# Altium schematic sheet COMMUNICATION.SchDoc(COMMUNICATION)
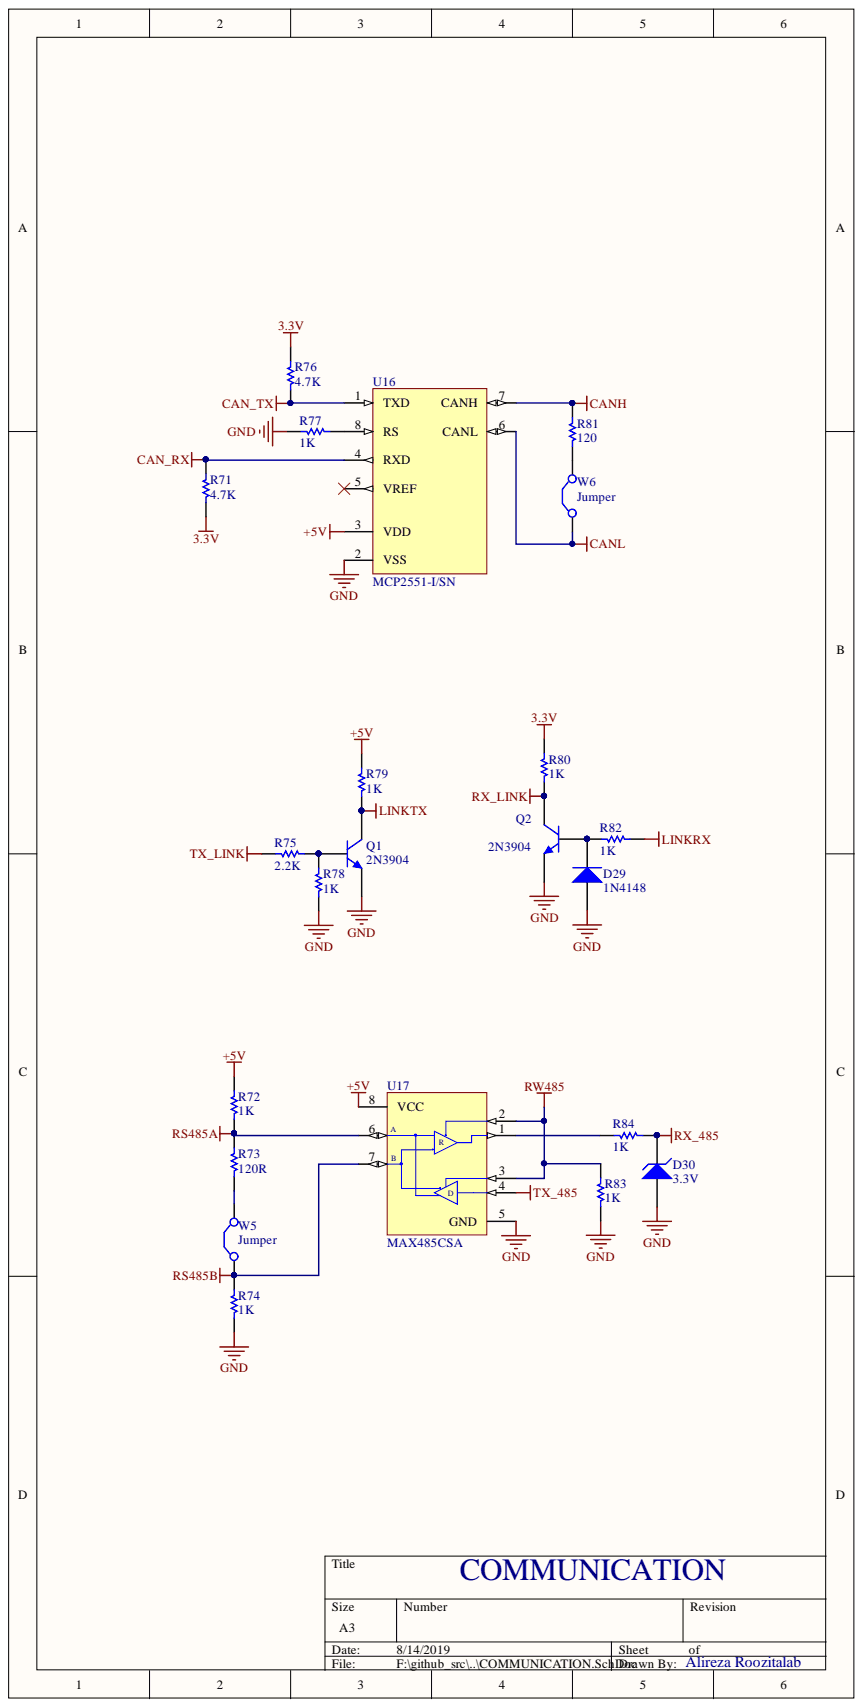
[diagram p0: COMMUNICATION.SchDoc]

## Parts
D29  1N4148  [Diode-1n4148 - SMD PAD]
D30  3.3V  [Diode-1n4148 - SMD PAD]
Q1  2N3904  [2N3906_SMD]
Q2  2N3904  [2N3906_SMD]
R71  value 4.7K  [RES 1206 (3216X08L)]  (hidden comment: Res Semi)
R72  value 1K  [RES 1206 (3216X08L)]  (hidden comment: Res Semi)
R73  value 120R  [RES 1206 (3216X08L)]  (hidden comment: Res Semi)
R74  value 1K  [RES 1206 (3216X08L)]  (hidden comment: Res Semi)
R75  value 2.2K  [RES 1206 (3216X08L)]  (hidden comment: Res Semi)
R76  value 4.7K  [RES 1206 (3216X08L)]  (hidden comment: Res Semi)
R77  value 1K  [RES 1206 (3216X08L)]  (hidden comment: Res Semi)
R78  value 1K  [RES 1206 (3216X08L)]  (hidden comment: Res Semi)
R79  value 1K  [RES 1206 (3216X08L)]  (hidden comment: Res Semi)
R80  value 1K  [RES 1206 (3216X08L)]  (hidden comment: Res Semi)
R81  value 120  [RES 1206 (3216X08L)]  (hidden comment: Res Semi)
R82  value 1K  [RES 1206 (3216X08L)]  (hidden comment: Res Semi)
R83  value 1K  [RES 1206 (3216X08L)]  (hidden comment: Res Semi)
R84  value 1K  [RES 1206 (3216X08L)]  (hidden comment: Res Semi)
U16  MCP2551-I/SN  [SOP8]
U17  MAX485CSA  [SOP8]
W5  Jumper  [RES 0402 (1005X04L)]
W6  Jumper  [RES 0402 (1005X04L)]

## Nets
3.3V: R71.2 R76.1 R80.1
+5V: R72.2 R79.1 U16.3(VDD) U17.8(VCC)
CAN_RX: R71.1 U16.4(RXD)
CAN_TX: R76.2 U16.1(TXD)
CANH: R81.1 U16.7(CANH)
CANL: U16.6(CANL) W6.1
GND: D29.1 D30.1 Q1.1 Q2.1 R74.1 R77.1 R78.2 R83.1 U16.2(VSS) U17.5(GND)
LINKRX: R82.2
LINKTX: Q1.3 R79.2
RS485A: R72.1 R73.2 U17.6
RS485B: R74.2 U17.7 W5.1
RW485: R83.2 U17.2 U17.3
RX_485: D30.2 R84.1
RX_LINK: Q2.3 R80.2
TX_485: U17.4
TX_LINK: R75.2
NetD29_2: D29.2 Q2.2 R82.1
NetQ1_2: Q1.2 R75.1 R78.1
NetR73_1: R73.1 W5.2
NetR77_2: R77.2 U16.8(RS)
NetR81_2: R81.2 W6.2
NetR84_2: R84.2 U17.1

Not connected: U16 5(VREF)

## Library data of the parts
D29 = Diode: Default Diode; Simulation: DIODE; Signal Integrity: Diode; Published: 8-Jun-2000; PackageReference: SMC; PackageDocument: Sep-1996; Library Name: Miscellaneous Devices.IntLib; LatestRevisionNote: Re-released for DXP Platform.; LatestRevisionDate: 17-Jul-2002; Component Kind: Standard; Code_JEDEC: DO-214-AB; Publisher: Altium Limited
D30 = D Zener: Zener Diode; Simulation: ZENER; Signal Integrity: D Zener; Published: 8-Jun-2000; PackageReference: DIODE-0.7; Library Name: Miscellaneous Devices.IntLib; LatestRevisionNote: Re-released for DXP Platform.; LatestRevisionDate: 17-Jul-2002; Component Kind: Standard; Publisher: Altium Limited
Q1 Q2 = 2N3904: NPN General Purpose Amplifier; Simulation: 2N3904; Signal Integrity: 2N3904; Published: 8-Jun-2000; PackageReference: TO-92A; PackageDocument: Sep-1998; Library Name: Miscellaneous Devices.IntLib; LatestRevisionNote: PCB Footprint 'BCY-W3/D4.7' replaced; LatestRevisionDate: 15-Jan-2003; DatasheetDocument: 1997; ComponentLink2URL: http://www.fairchildsemi.com/ds/2N%2F2N3904.pdf; ComponentLink2Description: Datasheet; ComponentLink1URL: http://www.fairchildsemi.com/; ComponentLink1Description: Manufacturer Link; Component Kind: Standard; Code_JEDEC: TO-92A; Publisher: Altium Limited
R71-R84 = Res Semi: Semiconductor Resistor; Simulation: RES; Signal Integrity: Res Semi; Published: 8-Jun-2000; PackageReference: AXIAL-0.5; Library Name: Miscellaneous Devices.IntLib; LatestRevisionNote: Re-released for DXP Platform.; LatestRevisionDate: 17-Jul-2002; Component Kind: Standard; Publisher: Altium Limited
U16 = MCP2551-I/SN: High-Speed CAN Transceiver, 8-Pin SOIC, Industrial Temperature; Manufacturer: Microchip; Supplier Part Number 4: 579-MCP2551-I/SN; Supplier Part Number 3: 69K7604; Supplier Part Number 2: 9758569; Supplier Part Number 1: MCP2551-I/SN-ND; Supplier 4: Mouser; Supplier 3: Newark; Supplier 2: Farnell; Supplier 1: Digi-Key; PartNumber: MCP2551-I/SN; PackageVersion: revBB, Aug-2009; PackageReference: SOIC-SN8; PackageDescription: 8-Lead Plastic Small Outline (SN) - Narrow, 3.90mm Body [SOIC]; Mounting Technology: Surface Mount; Library Name: Microchip Interface.IntLib; DatasheetVersion: revF, Jul-2010; ComponentLink3URL: http://www.microchip.com/stellent/groups/techpub_sg/documents/packagingspec/en012702.pdf; ComponentLink3Description: Package Specification; ComponentLink2URL: http://ww1.microchip.com/downloads/en/DeviceDoc/21667F.pdf; ComponentLink2Description: Datasheet; ComponentLink1URL: http://www.microchip.com/; ComponentLink1Description: Manufacturer URL; Component Kind: Standard; Code_JEDEC: MS-012; Code_IPC: SOIC127P600-8
U17 = MAX485CSA: Low-Power, Slew-Rate-Limited RS-485/RS-422 Transceiver; Published: 16-Jan-2001; PCB3D: NSO8; PackageReference: NSO8; PackageDescription: 8-Pin Small Outline Integrated Circuit 1.27 mm Pitch; Library Name: Maxim Communication Transceiver.IntLib; LatestRevisionNote: IPC-7351 Footprint Added.; LatestRevisionDate: 13-Apr-2006; DatasheetVersion: Feb-1996; ComponentLink2URL: http://pdfserv.maxim-ic.com/en/ds/MAX1487-MAX491.pdf; ComponentLink2Description: Datasheet; ComponentLink1URL: http://www.maxim-ic.com/; ComponentLink1Description: Manufacturer Link; Component Kind: Standard; Code_JEDEC: MS-012-AA; Code_IPC: SOIC127P600-8; Publisher: Altium Limited
W5 W6 = Jumper: Jumper Wire; Published: 24-Mar-1999; PackageReference: RAD-0.2; Library Name: Miscellaneous Devices.IntLib; LatestRevisionNote: Re-released for DXP Platform.; LatestRevisionDate: 17-Jul-2002; Component Kind: Standard; Publisher: Altium Limited
